# Altium schematic sheet Modules_TP.SchDoc(Modules_TP)
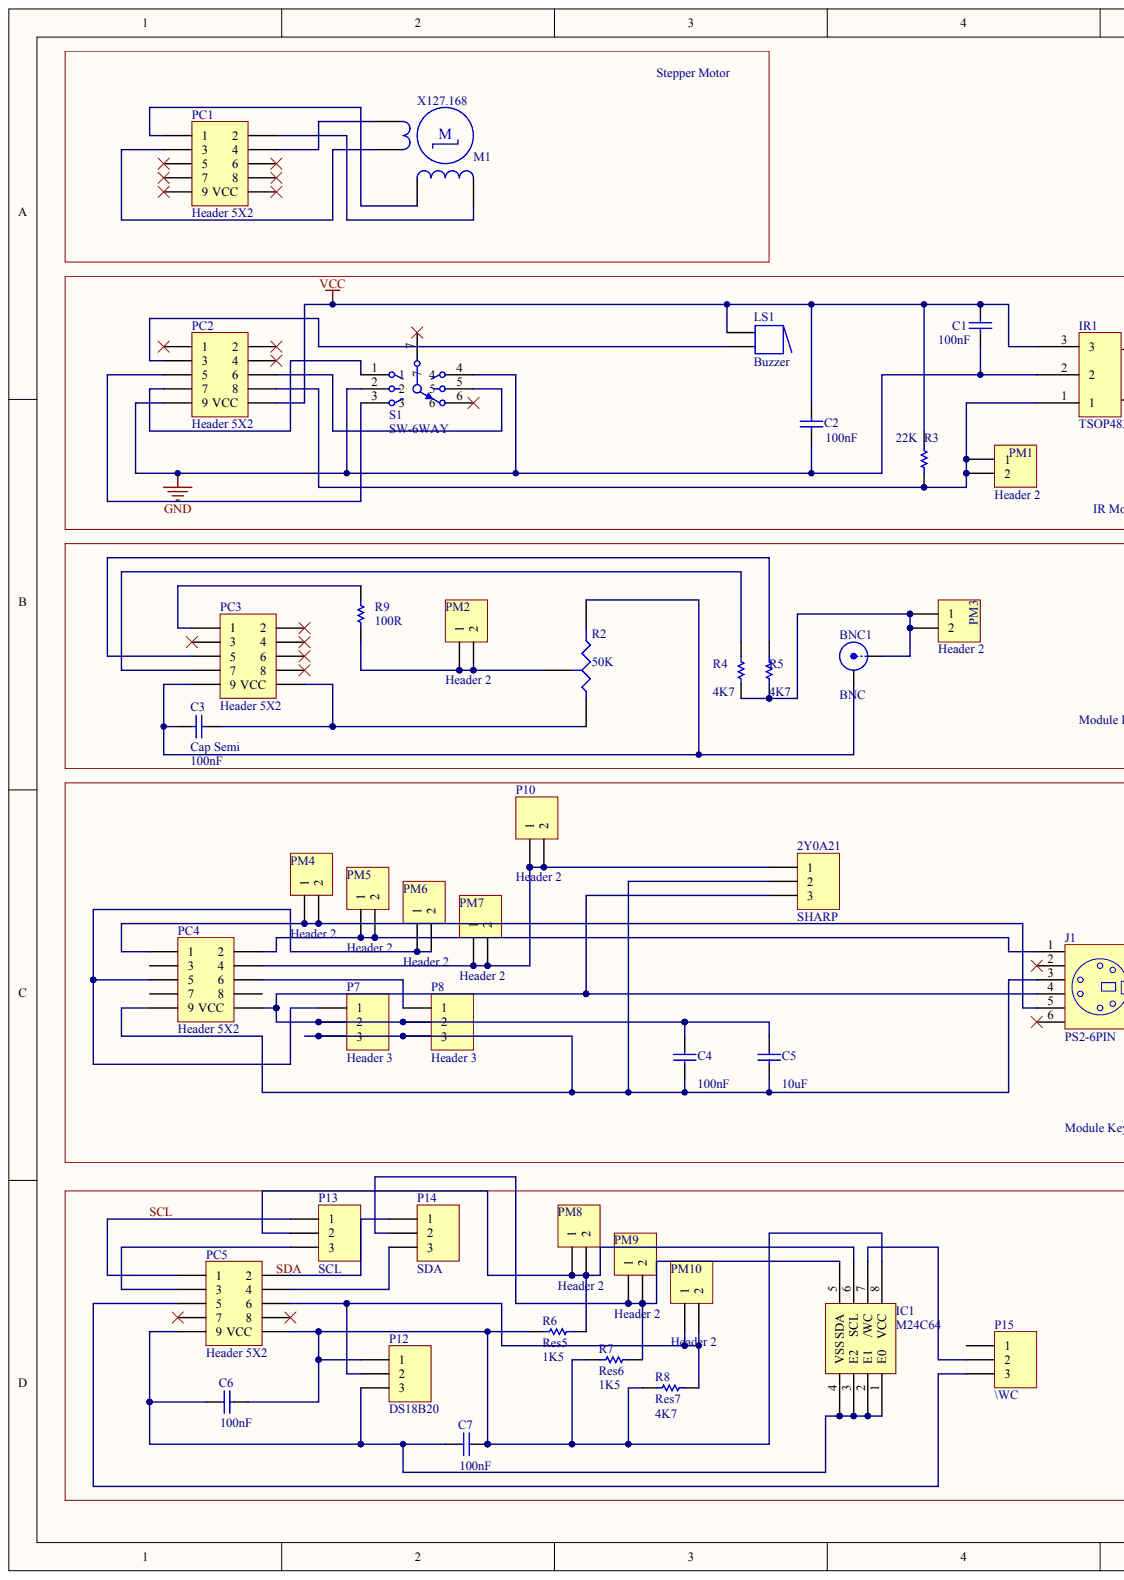
[diagram p0: Modules_TP.SchDoc, left]
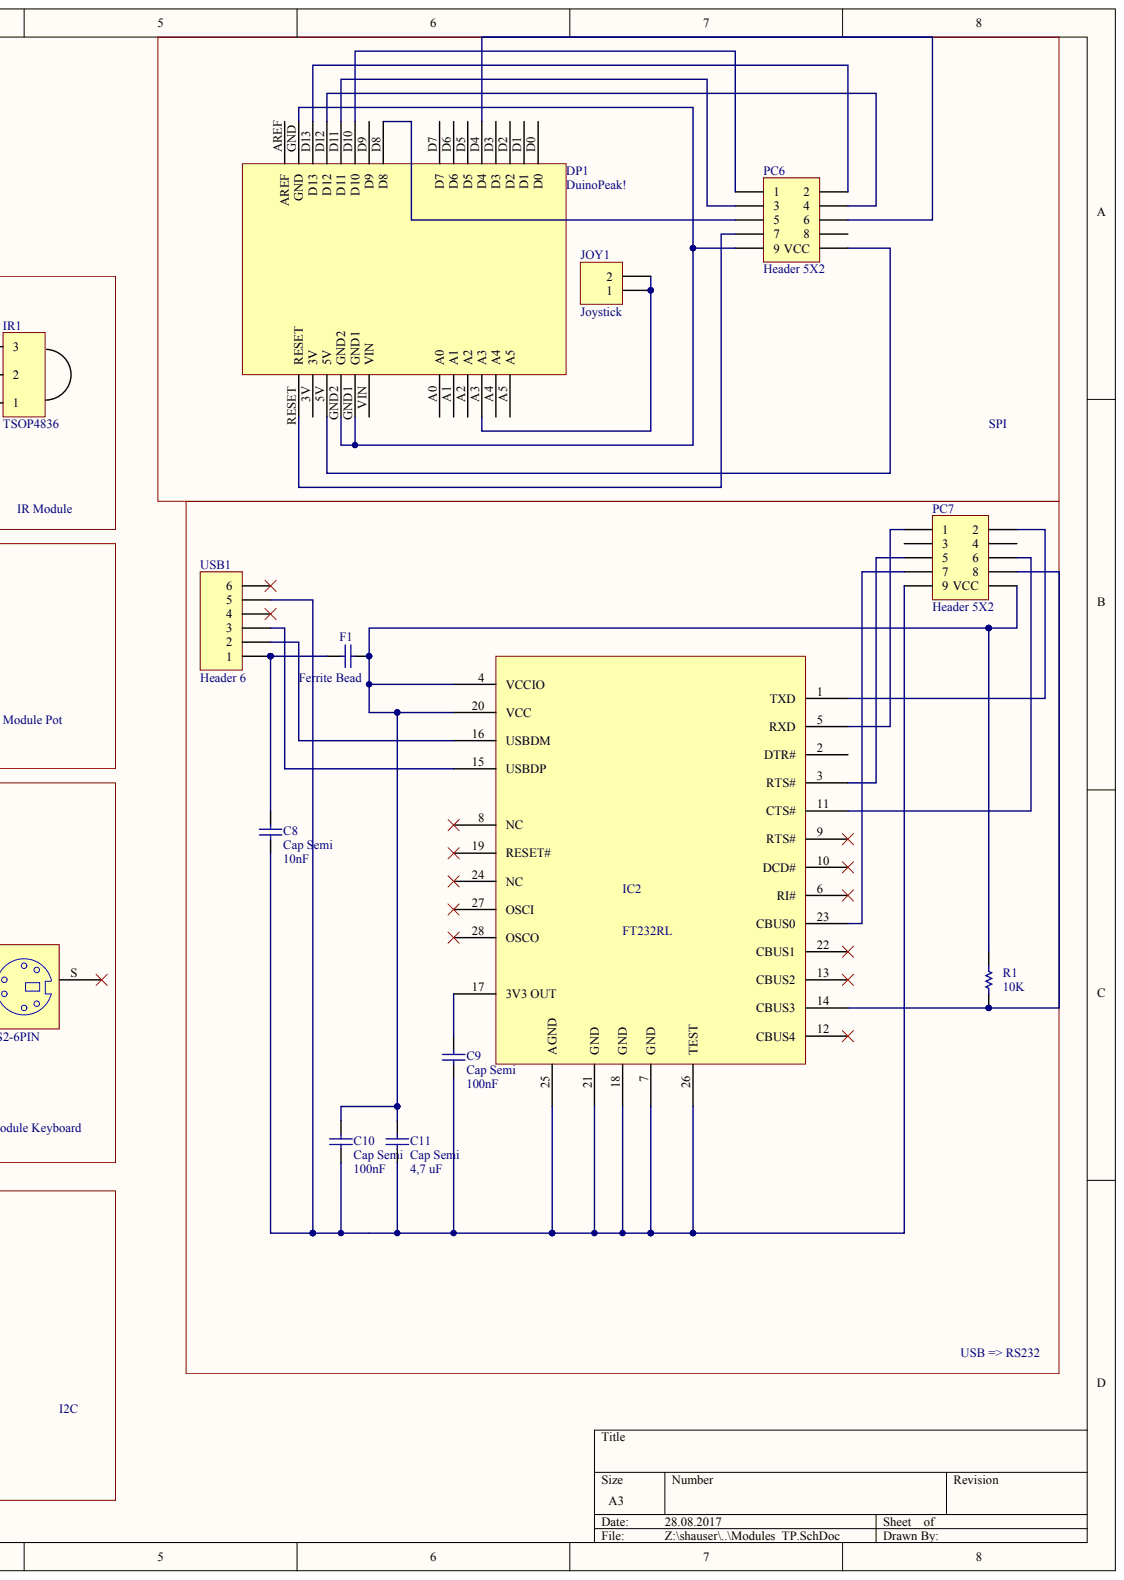
[diagram p1: Modules_TP.SchDoc, right]

## Parts
2Y0A21  SHARP
BNC1  BNC
C1  value 100nF  (hidden comment: Cap Semi)
C2  value 100nF  (hidden comment: Cap Semi)
C3  Cap Semi  value 100nF
C4  value 100nF  (hidden comment: Cap Semi)
C5  value 10uF  (hidden comment: Cap Semi)
C6  value 100nF  (hidden comment: Cap Semi)
C7  value 100nF  (hidden comment: Cap Semi)
C8  Cap Semi  value 10nF
C9  Cap Semi  value 100nF
C10  Cap Semi  value 100nF
C11  Cap Semi  value 4,7 uF
DP1  DuinoPeak!
F1  Ferrite Bead
IC1  M24C64
IC2  FT232RL
IR1  TSOP4836
J1  PS2-6PIN
JOY1  Joystick
LS1  Buzzer
M1  X127.168
P7  Header 3
P8  Header 3
P10  Header 2
P12  DS18B20
P13  SCL
P14  SDA
P15  \WC
PC1  Header 5X2
PC2  Header 5X2
PC3  Header 5X2
PC4  Header 5X2
PC5  Header 5X2
PC6  Header 5X2
PC7  Header 5X2
PM1  Header 2
PM2  Header 2
PM3  Header 2
PM4  Header 2
PM5  Header 2
PM6  Header 2
PM7  Header 2
PM8  Header 2
PM9  Header 2
PM10  Header 2
R1  value 10K  (hidden comment: Res?)
R2  value 50K  (hidden comment: Res Tap)
R3  value 22K  (hidden comment: Res1)
R4  value 4K7  (hidden comment: Res2)
R5  value 4K7  (hidden comment: Res3)
R6  Res5  value 1K5
R7  Res6  value 1K5
R8  Res7  value 4K7
R9  value 100R  (hidden comment: Res4)
S1  SW-6WAY
USB1  Header 6

## Nets
GND: C1.1 C2.1 IR1.2 PC2.9 S1.2 S1.4
VCC: C1.2 C2.2 IR1.3 LS1.1 PC2.10(VCC) R3.2
SCL: P13.1 PC5.1
Net2Y0A21_1: 2Y0A21.1 P10.1 P10.2 PC4.4 PM7.1 PM7.2
Net2Y0A21_2: 2Y0A21.2 C4.2 C5.2 J1.3 P7.3 P8.3 PC4.9
Net2Y0A21_3: 2Y0A21.3 C4.1 C5.1 J1.4 P7.2 P8.2 PC4.10(VCC)
NetBNC1_1: BNC1.1 PM3.1 PM3.2 R4.1 R5.1
NetBNC1_2: BNC1.2 C3.2 PC3.9 R2.3
NetC3_1: C3.1 PC3.10(VCC) R2.1
NetC6_1: C6.1 C7.2 IC1.8(VCC) P12.1 PC5.10(VCC) R6.2 R7.2 R8.2
NetC6_2: C6.2 C7.1 IC1.1(E0) IC1.2(E1) IC1.3(E2) IC1.4(VSS) P12.3 PC5.9
NetC8_1: C8.1 F1.1 USB1.1
NetC8_2: C8.2 C9.2 C10.2 C11.2 IC2.7(GND) IC2.18(GND) IC2.21(GND) IC2.25(AGND) IC2.26(TEST) PC7.9 USB1.5
NetC9_1: C9.1 IC2.17(3V3_OUT)
NetC10_1: C10.1 C11.1 F1.2 IC2.4(VCCIO) IC2.20(VCC) PC7.10(VCC) R1.2
NetDP1_5V: DP1.5V PC6.10(VCC)
NetDP1_A3: DP1.A3 JOY1.1 JOY1.2
NetDP1_D4: DP1.D4 PC6.6
NetDP1_D8: DP1.D8 PC6.5
NetDP1_D10: DP1.D10 PC6.1
NetDP1_D11: DP1.D11 PC6.3
NetDP1_D12: DP1.D12 PC6.4
NetDP1_D13: DP1.D13 PC6.2
NetDP1_GND: DP1.GND DP1.GND1 DP1.GND2 PC6.9
NetDP1_RESET: DP1.RESET PC6.7
NetIC1_5: IC1.5(SDA) P14.2 PM9.1 PM9.2 R7.1
NetIC1_6: IC1.6(SCL) P13.2 PM8.1 PM8.2 R6.1
NetIC1_7: IC1.7(/WC) P15.2
NetIC2_1: IC2.1(TXD) PC7.2
NetIC2_3: IC2.3(RTS#) PC7.5
NetIC2_5: IC2.5(RXD) PC7.1
NetIC2_11: IC2.11(CTS#) PC7.6
NetIC2_14: IC2.14(CBUS3) PC7.8 R1.1
NetIC2_15: IC2.15(USBDP) USB1.3
NetIC2_16: IC2.16(USBDM) USB1.2
NetIC2_23: IC2.23(CBUS0) PC7.7
NetIR1_1: IR1.1 PC2.8 PM1.1 PM1.2 R3.1
NetJ1_1: J1.1 PC4.2 PM5.1 PM5.2
NetJ1_5: J1.5 PC4.1 PM4.1 PM4.2
NetLS1_2: LS1.2 PC2.3
NetM1_1: M1.1 PC1.4
NetM1_3: M1.3 PC1.3
NetM1_4: M1.4 PC1.1
NetM1_6: M1.6 PC1.2
NetP7_1: P7.1 PC4.5 PM6.1 PM6.2
NetP8_1: P8.1 PC4.6
NetP12_2: P12.2 PC5.6 PM10.1 PM10.2 R8.1
NetP13_3: P13.3 PC5.3
NetP14_1: P14.1 PC5.2
NetP14_3: P14.3 PC5.4
NetP15_3: P15.3 PC5.5
NetPC2_5: PC2.5 S1.3
NetPC2_6: PC2.6 S1.5
NetPC2_7: PC2.7 S1.1
NetPC3_1: PC3.1 R9.2
NetPC3_5: PC3.5 R5.2
NetPC3_7: PC3.7 R4.2
NetPM2_1: PM2.1 PM2.2 R2.2 R9.1
SDA:

Not connected: DP1 3V A0 A1 A2 A4 A5 AREF D0 D1 D2 D3 D5 D6 D7 D9 VIN; IC2 2(DTR#) 6(RI#) 8(NC) 9(RTS#) 10(DCD#) 12(CBUS4) 13(CBUS2) 19(RESET#) 22(CBUS1) 24(NC) 27(OSCI) 28(OSCO); J1 2 6 S; P15 1; PC1 5 6 7 8 9 10(VCC); PC2 1 2 4; PC3 2 3 4 6 8; PC4 3 7 8; PC5 7 8; PC6 8; PC7 3 4; S1 6 7; USB1 4 6

## Library data of the parts
R2 = (no symbol name): Sim Note: Enter the Resistance value and the set point into parameter fields.; Set Position: 0.5; Published: 24-Mar-1999; LatestRevisionDate: 17-Jul-2002; LatestRevisionNote: Re-released for DXP Platform.; PackageReference: VR3; PackageDescription: Variable Resistor, Thru-Hole; 3 Leads; Publisher: Altium Limited
2Y0A21 BNC1 C1-C11 DP1 F1 IC1 IC2 IR1 J1 JOY1 LS1 M1 P7 P8 P10 P12-P15 PC1-PC7 PM1-PM10 R1 R3-R9 S1 USB1 = (no symbol name)
  2Y0A21 BNC1 JOY1 P7 P8 P10 P12-P15 PC1-PC7 PM1-PM10 USB1: LatestRevisionDate: 17-Jul-2002; LatestRevisionNote: Re-released for DXP Platform.
  C1-C11 F1: Published: 8-Jun-2000; LatestRevisionDate: 29-May-2009; LatestRevisionNote: IPC-7351 Footprint Added.; PackageReference: C1206; PackageDescription: Chip Capacitor
  J1: Published: 17-Dec-2003; ComponentLink1Description: Manufacturer Link; ComponentLink1URL: http://www.oupiin.com/prodindx.html#Headers
  LS1: Published: 24-Mar-1999; LatestRevisionDate: 17-Dec-2003; LatestRevisionNote: Schematic revised, PCB Footprint replaced.; DatasheetVersion: 17-Dec-2003; PackageReference: ABSM-1574; PackageDescription: Magnetic Transducer Buzzer; ComponentLink1Description: Manufacturer Link; ComponentLink1URL: http://www.megastar.com/linecard/buzzmode/index.php
  M1: Published: 24-Mar-1999; LatestRevisionDate: 17-Jul-2002; LatestRevisionNote: Re-released for DXP Platform.; PackageReference: DIP-6; Code_JEDEC: MO-001-AM
  R1 R3-R9: Published: 8-Jun-2000; LatestRevisionDate: 17-Jul-2002; LatestRevisionNote: Re-released for DXP Platform.; PackageReference: AXIAL-0.3; PackageDescription: Axial Device, Thru-Hole; 2 Leads; 0.3 in Pin Spacing
  S1: Published: 24-Mar-1999; LatestRevisionDate: 17-Jul-2002; LatestRevisionNote: Re-released for DXP Platform.; PackageReference: SW-7; PackageDescription: Switch; 7 Leads
  2Y0A21 BNC1 C1-C11 F1 J1 JOY1 LS1 M1 P7 P8 P10 P12-P15 PC1-PC7 PM1-PM10 R1 R3-R9 S1 USB1: Publisher: Altium Limited
